# Altium schematic sheet HDS-TeenSy.SchDoc(HDS-TeenSy)
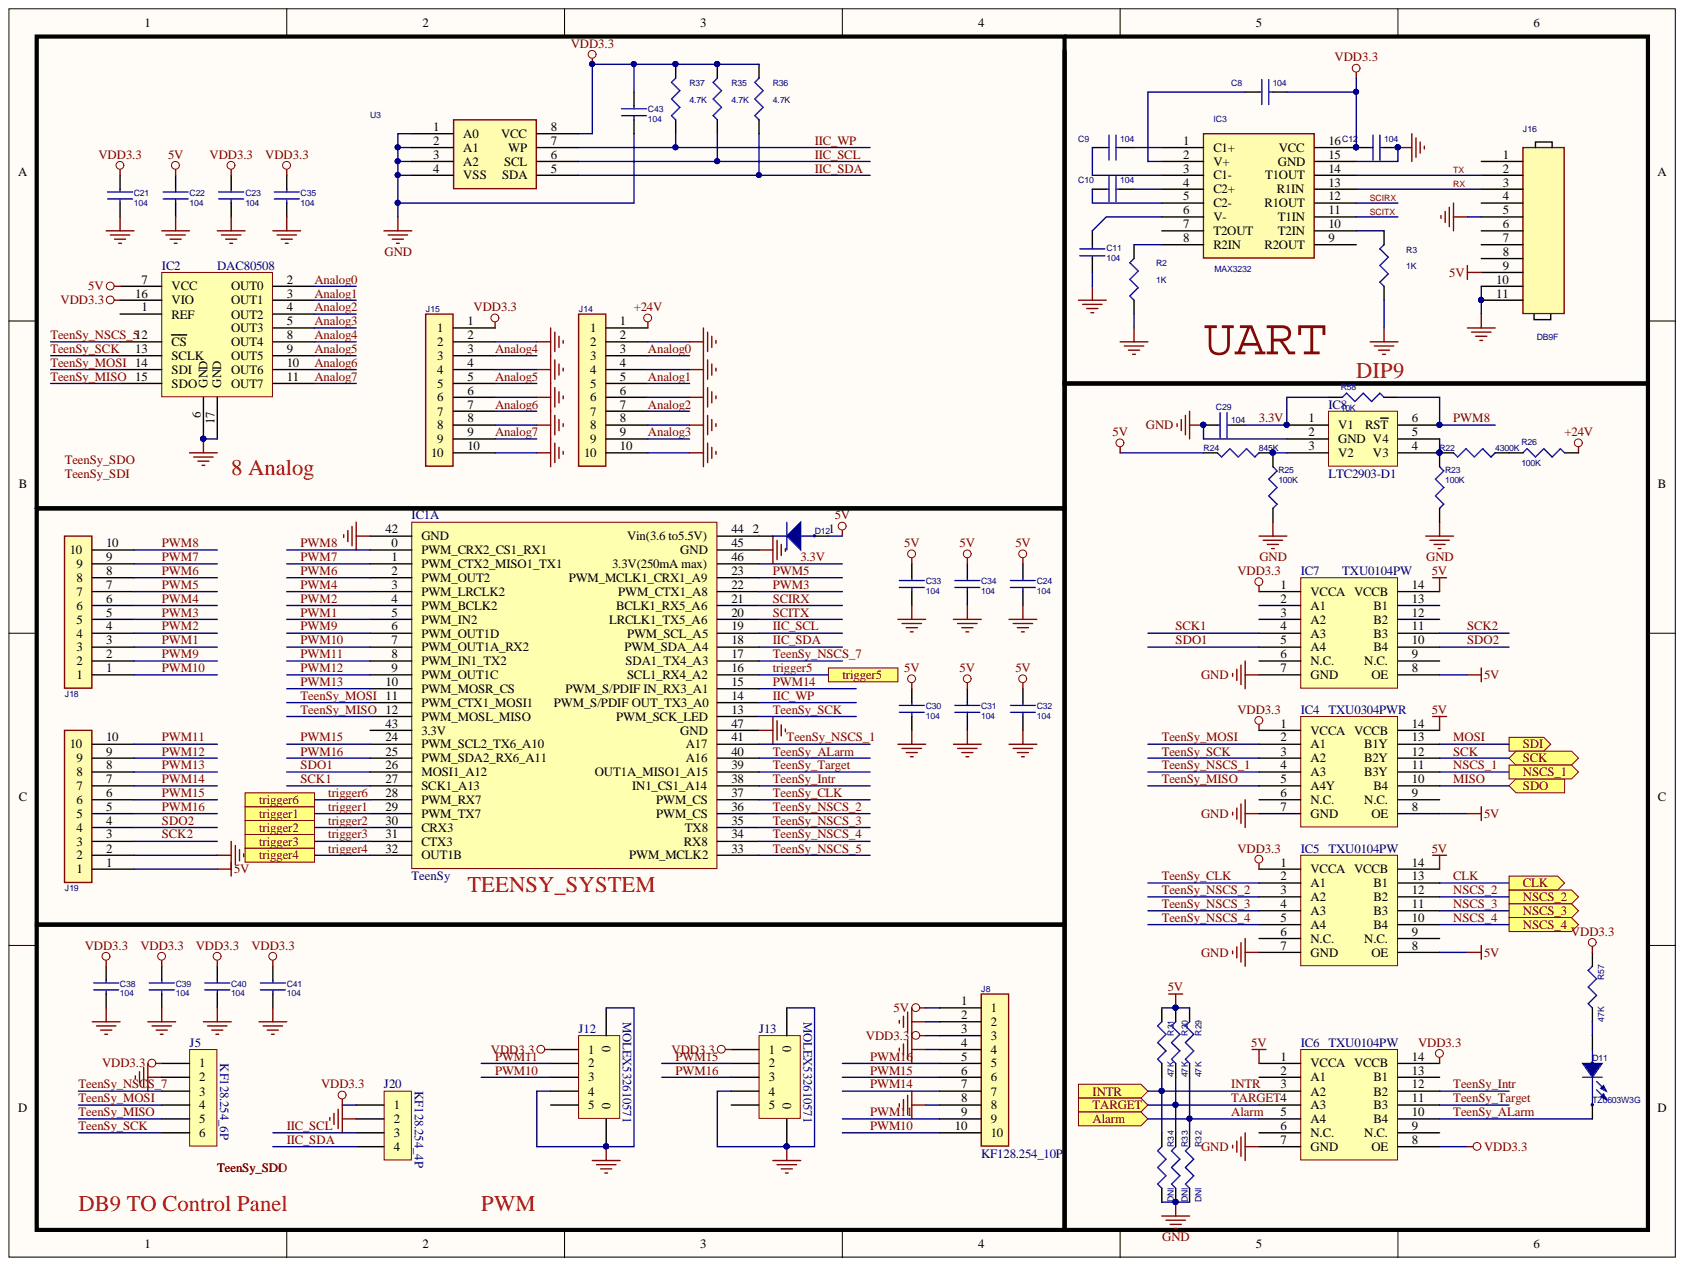
[diagram p0: HDS-TeenSy.SchDoc]

## Parts
C8  104  [0603C]
C9  104  [0603C]
C10  104  [0603C]
C11  104  [0603C]
C12  104  [0603C]
C21  104  [0603C]
C22  104  [0603C]
C23  104  [0603C]
C24  104  [0603C]
C29  104  [0603C]
C30  104  [0603C]
C31  104  [0603C]
C32  104  [0603C]
C33  104  [0603C]
C34  104  [0603C]
C35  104  [0603C]
C38  104  [0603C]
C39  104  [0603C]
C40  104  [0603C]
C41  104  [0603C]
C43  104  [0603C]
D11  TZ0603W3G  [D0603]
D12  SS34  [d1210]  (value not drawn)
IC1  TeenSy  [CON40A]  (part A)
IC2  DAC80508  [PWQFN16]
IC3  MAX3232  [SO-16]
IC4  TXU0304PWR  [TSSOP14]
IC5  TXU0104PW  [TSSOP14]
IC6  TXU0104PW  [TSSOP14]
IC7  TXU0104PW  [TSSOP14]
IC8  LTC2903-D1
J5  KF128.254_6P  [KF128.254_6P]
J8  KF128.254_10P  [KF128.254_10P]
J12  MOLEX532610571  [MOLEX532610571]
J13  MOLEX532610571  [MOLEX532610571]
J14  J10  [SIP-10P]  (value not drawn)
J15  J10  [SIP-10P]  (value not drawn)
J16  DB9F  [DB9-180-F]
J18  J10  [SIP-10P]  (value not drawn)
J19  J10  [SIP-10P]  (value not drawn)
J20  KF128.254_4P  [KF128.254_4P]
R2  1K  [0603R]
R3  1K  [0603R]
R22  4300K  [0603R]
R23  100K  [0603R]
R24  845K  [0603R]
R25  100K  [0603R]
R26  100K  [0603R]
R29  47K  [0603R]
R30  47K  [0603R]
R31  47K  [0603R]
R32  DNI  [0603R]
R33  DNI  [0603R]
R34  DNI  [0603R]
R35  4.7K  [0603R]
R36  4.7K  [0603R]
R37  4.7K  [0603R]
R57  47K  [0603R]
R58  10K  [0603R]
U3  (no value)  [SO8NB]

## Ports
Alarm, CLK, INTR, NSCS_1, NSCS_2, NSCS_3, NSCS_4, SCK, SDI, SDO, TARGET, trigger1, trigger2, trigger3, trigger4, trigger5, trigger6

## Nets
5V: C22.1 C24.1 C30.1 C31.1 C32.1 C33.1 C34.1 D12.1 IC2.7(VCC) IC4.8(OE) IC4.14(VCCB) IC5.8(OE) IC5.14(VCCB) IC6.1(VCCA) IC7.8(OE) IC7.14(VCCB) J8.1 J16.9 J19.1 R24.2 R29.2 R30.2 R31.2
+24V: J14.1 R26.2
GGND: J16.10 J16.11
GND: C11.1 C12.2 C21.2 C22.2 C23.2 C24.2 C29.1 C30.2 C31.2 C32.2 C33.2 C34.2 C35.2 C38.2 C39.2 C40.2 C41.2 C43.2 IC1.42(GND) IC1.45(GND) IC1.47(GND) IC2.6(GND) IC2.17(GND) IC3.15(GND) IC4.7(GND) IC5.7(GND) IC6.7(GND) IC7.7(GND) IC8.2(GND) J5.2 J8.2 J8.4 J8.8 J12.0 J12.4 J13.0 J13.4 J14.2 J14.4 J14.6 J14.8 J14.10 J15.2 J15.4 J15.6 J15.8 J15.10 J16.5 J19.2 J20.2 R2.1 R3.1 R23.2 R25.2 R32.1 R33.1 R34.1 U3.1(A0) U3.2(A1) U3.3(A2) U3.4(VSS)
VDD3.3: C8.2 C12.1 C21.1 C23.1 C35.1 C38.1 C39.1 C40.1 C41.1 C43.1 IC2.16(VIO) IC3.16(VCC) IC4.1(VCCA) IC5.1(VCCA) IC6.8(OE) IC6.14(VCCB) IC7.1(VCCA) J5.1 J8.3 J12.1 J13.1 J15.1 J20.1 R35.2 R36.2 R37.2 R57.2 U3.8(VCC)
3.3V: C29.2 IC1.46(3.3V(250mA_max)) IC8.1(V1) R58.1
Alarm: IC6.5(A4) R29.1 R32.2 ; port Alarm
Analog0: IC2.2(OUT0) J14.3
Analog1: IC2.3(OUT1) J14.5
Analog2: IC2.4(OUT2) J14.7
Analog3: IC2.5(OUT3) J14.9
Analog4: IC2.8(OUT4) J15.3
Analog5: IC2.9(OUT5) J15.5
Analog6: IC2.10(OUT6) J15.7
Analog7: IC2.11(OUT7) J15.9
CLK: IC5.13(B1) ; port CLK
IIC_SCL: IC1.19(PWM_SCL_A5) J20.3 R35.1 U3.6(SCL)
IIC_SDA: IC1.18(PWM_SDA_A4) J20.4 R36.1 U3.5(SDA)
IIC_WP: IC1.14(PWM_S/PDIF_OUT_TX3_A0) R37.1 U3.7(WP)
INTR: IC6.3(A2) R31.1 R34.2 ; port INTR
MISO: IC4.10(B4) ; port SDO
MOSI: IC4.13(B1Y) ; port SDI
NSCS_1: IC4.11(B3Y) ; port NSCS_1
NSCS_2: IC5.12(B2) ; port NSCS_2
NSCS_3: IC5.11(B3) ; port NSCS_3
NSCS_4: IC5.10(B4) ; port NSCS_4
PWM1: IC1.5(PWM_IN2) J18.3
PWM2: IC1.4(PWM_BCLK2) J18.4
PWM3: IC1.22(PWM_CTX1_A8) J18.5
PWM4: IC1.3(PWM_LRCLK2) J18.6
PWM5: IC1.23(PWM_MCLK1_CRX1_A9) J18.7
PWM6: IC1.2(PWM_OUT2) J18.8
PWM7: IC1.1(PWM_CTX2_MISO1_TX1) J18.9
PWM8: IC1.0(PWM_CRX2_CS1_RX1) IC8.6(RST) J18.10 R58.2
PWM9: IC1.6(PWM_OUT1D) J18.2
PWM10: IC1.7(PWM_OUT1A_RX2) J8.10 J12.3 J18.1
PWM11: IC1.8(PWM_IN1_TX2) J8.9 J12.2 J19.10
PWM12: IC1.9(PWM_OUT1C) J19.9
PWM13: IC1.10(PWM_MOSR_CS) J19.8
PWM14: IC1.15(PWM_S/PDIF_IN_RX3_A1) J8.7 J19.7
PWM15: IC1.24(PWM_SCL2_TX6_A10) J8.6 J13.2 J19.6
PWM16: IC1.25(PWM_SDA2_RX6_A11) J8.5 J13.3 J19.5
RX: IC3.13(R1IN) J16.3
SCIRX: IC1.21(BCLK1_RX5_A6) IC3.12(R1OUT)
SCITX: IC1.20(LRCLK1_TX5_A6) IC3.11(T1IN)
SCK: IC4.12(B2Y) ; port SCK
SCK1: IC1.27(SCK1_A13) IC7.4(A3)
SCK2: IC7.11(B3) J19.3
SDO1: IC1.26(MOSI1_A12) IC7.5(A4)
SDO2: IC7.10(B4) J19.4
TARGET: IC6.4(A3) R30.1 R33.2 ; port TARGET
TeenSy_ALarm: D11.2 IC1.40(A16) IC6.10(B4)
TeenSy_CLK: IC1.37(PWM_CS) IC5.2(A1)
TeenSy_Intr: IC1.38(IN1_CS1_A14) IC6.12(B2)
TeenSy_MISO: IC1.12(PWM_MOSL_MISO) IC2.15(SDO) IC4.5(A4Y) J5.5
TeenSy_MOSI: IC1.11(PWM_CTX1_MOSI1) IC2.14(SDI) IC4.2(A1) J5.4
TeenSy_NSCS_1: IC1.41(A17) IC4.4(A3)
TeenSy_NSCS_2: IC1.36(PWM_CS) IC5.3(A2)
TeenSy_NSCS_3: IC1.35(TX8) IC5.4(A3)
TeenSy_NSCS_4: IC1.34(RX8) IC5.5(A4)
TeenSy_NSCS_5: IC1.33(PWM_MCLK2) IC2.12(CS)
TeenSy_NSCS_7: IC1.17(SDA1_TX4_A3) J5.3
TeenSy_SCK: IC1.13(PWM_SCK_LED) IC2.13(SCLK) IC4.3(A2) J5.6
TeenSy_Target: IC1.39(OUT1A_MISO1_A15) IC6.11(B3)
trigger1: IC1.29(PWM_TX7) ; port trigger1
trigger2: IC1.30(CRX3) ; port trigger2
trigger3: IC1.31(CTX3) ; port trigger3
trigger4: IC1.32(OUT1B) ; port trigger4
trigger5: IC1.16(SCL1_RX4_A2) ; port trigger5
trigger6: IC1.28(PWM_RX7) ; port trigger6
TX: IC3.14(T1OUT) J16.2
NetC8_1: C8.1 IC3.2(V+)
NetC9_1: C9.1 IC3.3(C1-)
NetC9_2: C9.2 IC3.1(C1+)
NetC10_1: C10.1 IC3.5(C2-)
NetC10_2: C10.2 IC3.4(C2+)
NetC11_2: C11.2 IC3.6(V-)
NetD11_1: D11.1 R57.1
NetD12_2: D12.2 IC1.44(Vin(3.6_to5.5V))
NetIC3_8: IC3.8(R2IN) R2.2
NetIC3_10: IC3.10(T2IN) R3.2
NetIC8_3: IC8.3(V2) R24.1 R25.1
NetIC8_4: IC8.4(V3) IC8.5(V4) R22.2 R23.1
NetR22_1: R22.1 R26.1
TeenSy_SDI:

Not connected: IC1 43(3.3V); IC2 1(REF); IC3 7(T2OUT) 9(R2OUT); IC4 6(N.C.) 9(N.C.); IC5 6(N.C.) 9(N.C.); IC6 2(A1) 6(N.C.) 9(N.C.) 13(B1); IC7 2(A1) 3(A2) 6(N.C.) 9(N.C.) 12(B2) 13(B1); J12 5; J13 5; J16 1 4 6 7 8

## Library data of the parts
C8-C12 C21-C24 C29-C35 C38-C41 C43 = CAP NP: Component Kind: Standard
D11 = MR3050: Component Kind: Standard
D12 = 1N5819_1: Component Kind: Standard
IC3 = MAX232: Component Kind: Standard
IC5-IC7 = NTS0104PW: Component Kind: Standard; Library Name: TeenSy_1.SCHLIB
IC8 = LTC2903-1: Component Kind: Standard; Library Name: TeenSy_1.SCHLIB
J5 = CON6: Component Kind: Standard; Library Name: TeenSy_1.SCHLIB
J8 = J10: Component Kind: Standard
J16 = HEADER 11: Component Kind: Standard
J20 = CON4: Component Kind: Standard; Library Name: TeenSy_1.SCHLIB
R2 R3 R22-R26 R29-R37 R57 R58 = R: Component Kind: Standard
U3 = 24LC128: Component Kind: Standard
IC1 = TeenSy: Component Kind: Standard; Library Name: TeenSy.SCHLIB
IC2 = DAC80508: Component Kind: Standard; Library Name: TeenSy.SCHLIB
IC4 = TXU0304PWR: Component Kind: Standard; Library Name: TeenSy_1.SCHLIB
J12 J13 = MOLEX532610571: Component Kind: Standard; Library Name: TeenSy_1.SCHLIB
J14 J15 J18 J19 = J10: Component Kind: Standard
